# Altium schematic sheet DIYson.SchDoc(DIYson) (continued)

## Library data of the parts
5V_USB +3V3 +5V +48V DIM1 DIM2 IO14 IO15 RX TX = Test_Point: Library Name: josh-lib.SchLib
C1 C3 = C1206 2.2uF 100V X7R 10%: Multilayer Ceramic Capacitor, 2.2 uF, 100 V, ± 10%, X7R, 1206 [3216 Metric]; Manufacturer: Yageo; Manufacturer Part Number: CC1206KKX7R0BB225; Capacitance: 2.2uF; Case/Package: 1206; Category: Ceramic Capacitors; Component Kind: Standard; ComponentLink1Description: http://www.yageo.com/documents/recent/UPY-NP0X7R_MV_100-to-630V_18.pdf?src-supplier=Digikey; ComponentLink1URL: http://www.yageo.com/documents/recent/UPY-NP0X7R_MV_100-to-630V_18.pdf?src-supplier=Digikey; ComponentLink2Description: http://www.yageo.com/documents/recent/UPY-NP0X7R_MV_100-to-630V_21.pdf?src-supplier=Digikey; ComponentLink2URL: http://www.yageo.com/documents/recent/UPY-NP0X7R_MV_100-to-630V_21.pdf?src-supplier=Digikey; ComponentLink3Description: https://www.yageo.com/upload/media/product/products/datasheet/mlcc/UPY-NP0X7R_MV_100-to-630V_21.pdf?src-supplier=Digikey; ComponentLink3URL: https://www.yageo.com/upload/media/product/products/datasheet/mlcc/UPY-NP0X7R_MV_100-to-630V_21.pdf?src-supplier=Digikey; ComponentLink4Description: https://datasheet.octopart.com/CC1206KKX7R0BB225-Yageo-datasheet-101324229.pdf?src-supplier=Newark; ComponentLink4URL: https://datasheet.octopart.com/CC1206KKX7R0BB225-Yageo-datasheet-101324229.pdf?src-supplier=Newark; ComponentLink5Description: https://datasheet.octopart.com/CC1206KKX7R0BB225-Yageo-datasheet-166511530.pdf?src-supplier=Future+Electronics; ComponentLink5URL: https://datasheet.octopart.com/CC1206KKX7R0BB225-Yageo-datasheet-166511530.pdf?src-supplier=Future+Electronics; ComponentLink6Description: LCSC; ComponentLink6URL: https://www.lcsc.com/product-detail/Multilayer-Ceramic-Capacitors-MLCC-SMD-SMT_YAGEO-CC1206KKX7R0BB225_C577211.html; Height: 1.9mm; Length: 0.126inch; Material: Ceramic; Max Operating Temperature: 125°C; Min Operating Temperature: -55°C; Mount: Surface Mount; Packaging: Tape and Reel; Pricing 1: 2000=0.125, 4000=0.123, 6000=0.121, 8000=0.12, 10000=0.117 (USD); RoHS: Yes; RoHS Compliant: Yes; Series: CC; Stock 1: 2718000; Supplier: LCSC; Supplier 1: Future Electronics; Supplier Part Number: C577211; Supplier Part Number 1: 3086827; Thickness: 0.057inch; Tolerance: 10%; Width: 0.063inch; Library Name: josh-lib.SchLib
C2 C4 = CC0603KRX7R7BB105: CAP CER 1UF 16V X7R 0603; Manufacturer: Yageo; Dissipation Factor: 5%; Tolerance: 10%; Capacitance: 1uF; Case Code (Imperial): 0603; Case Code (Metric): 1608; Case/Package: 0603; Category: Ceramic Capacitors; Component Kind: Standard; ComponentLink1Description: https://datasheet.ciiva.com/176/mlccorderingcode-176789.pdf?src-supplier=Digi-Key; ComponentLink1URL: https://datasheet.ciiva.com/176/mlccorderingcode-176789.pdf?src-supplier=Digi-Key; ComponentLink2Description: https://datasheet.ciiva.com/8033/1734573-8033801.pdf?src-supplier=Element14; ComponentLink2URL: https://datasheet.ciiva.com/8033/1734573-8033801.pdf?src-supplier=Element14; ComponentLink3Description: https://datasheet.ciiva.com/7978/1489800-7978143.pdf?src-supplier=Element14; ComponentLink3URL: https://datasheet.ciiva.com/7978/1489800-7978143.pdf?src-supplier=Element14; ComponentLink4Description: https://datasheet.ciiva.com/176/mlccorderingcode-176788.pdf?src-supplier=Digi-Key; ComponentLink4URL: https://datasheet.ciiva.com/176/mlccorderingcode-176788.pdf?src-supplier=Digi-Key; ComponentLink5Description: https://datasheet.ciiva.com/176/mlccorderingcode-176790.pdf?src-supplier=Digi-Key; ComponentLink5URL: https://datasheet.ciiva.com/176/mlccorderingcode-176790.pdf?src-supplier=Digi-Key; ComponentLink6Description: https://datasheet.ciiva.com/5425/upy-gphc-x7r-6-3v-to-50v-8-12304-5425495.pdf?src-supplier=Mouser; ComponentLink6URL: https://datasheet.ciiva.com/5425/upy-gphc-x7r-6-3v-to-50v-8-12304-5425495.pdf?src-supplier=Mouser; ComponentLink7Description: https://datasheet.ciiva.com/16625/upy-gphc-x7r-6-3v-to-50v-8-16625527.pdf?src-supplier=Avnet+Europe; ComponentLink7URL: https://datasheet.ciiva.com/16625/upy-gphc-x7r-6-3v-to-50v-8-16625527.pdf?src-supplier=Avnet+Europe; ComponentLink8Description: https://datasheet.ciiva.com/8033/1734573-8033800.pdf?src-supplier=Element14; ComponentLink8URL: https://datasheet.ciiva.com/8033/1734573-8033800.pdf?src-supplier=Element14; ComponentLink9Description: https://datasheet.ciiva.com/28450/1489800-28450557.pdf?src-supplier=Element14; ComponentLink9URL: https://datasheet.ciiva.com/28450/1489800-28450557.pdf?src-supplier=Element14; Composition: Ceramic; Depth: 0.8mm; Dielectric: X7R; Halogen Free: Halogen Free; Height: 0.8mm; Lead Free: Lead Free; Length: 1.6mm; Manufacturer Part Number: CC0603KRX7R7BB105; Max Operating Temperature: 125°C; Min Operating Temperature: -55°C; Mount: Surface Mount; Number of Pins: 2; Package Quantity: 1; Packaging: Tape and Reel; Pricing 1: 1=0.11000, 10=0.08000, 100=0.03560, 500=0.02544, 1000=0.02000 (USD); Radiation Hardening: No; REACH SVHC: Unknown; RoHS: Yes; Stock 1: 3285653; Supplier 1: Digi-Key; Supplier Part Number 1: 311-1446-6-ND; Termination: SMD/SMT; Thickness: 0.035inch; Voltage: 16V; Voltage Rating: 16V; Voltage Rating (DC): 16V; Width: 0.031inch; Library Name: josh-lib.SchLib
C5 = C1206 2.2uF 100V X7R 10%: Multilayer Ceramic Capacitor, 2.2 uF, 100 V, ± 10%, X7R, 1206 [3216 Metric]; Manufacturer: Yageo; Manufacturer Part Number: CC1206KKX7R0BB225; Voltage Rating: 100V; Capacitance: 2.2uF; Case/Package: 1206; Category: Ceramic Capacitors; Component Kind: Standard; ComponentLink1Description: http://www.yageo.com/documents/recent/UPY-NP0X7R_MV_100-to-630V_18.pdf?src-supplier=Digikey; ComponentLink1URL: http://www.yageo.com/documents/recent/UPY-NP0X7R_MV_100-to-630V_18.pdf?src-supplier=Digikey; ComponentLink2Description: http://www.yageo.com/documents/recent/UPY-NP0X7R_MV_100-to-630V_21.pdf?src-supplier=Digikey; ComponentLink2URL: http://www.yageo.com/documents/recent/UPY-NP0X7R_MV_100-to-630V_21.pdf?src-supplier=Digikey; ComponentLink3Description: https://www.yageo.com/upload/media/product/products/datasheet/mlcc/UPY-NP0X7R_MV_100-to-630V_21.pdf?src-supplier=Digikey; ComponentLink3URL: https://www.yageo.com/upload/media/product/products/datasheet/mlcc/UPY-NP0X7R_MV_100-to-630V_21.pdf?src-supplier=Digikey; ComponentLink4Description: https://datasheet.octopart.com/CC1206KKX7R0BB225-Yageo-datasheet-101324229.pdf?src-supplier=Newark; ComponentLink4URL: https://datasheet.octopart.com/CC1206KKX7R0BB225-Yageo-datasheet-101324229.pdf?src-supplier=Newark; ComponentLink5Description: https://datasheet.octopart.com/CC1206KKX7R0BB225-Yageo-datasheet-166511530.pdf?src-supplier=Future+Electronics; ComponentLink5URL: https://datasheet.octopart.com/CC1206KKX7R0BB225-Yageo-datasheet-166511530.pdf?src-supplier=Future+Electronics; ComponentLink6Description: LCSC; ComponentLink6URL: https://www.lcsc.com/product-detail/Multilayer-Ceramic-Capacitors-MLCC-SMD-SMT_YAGEO-CC1206KKX7R0BB225_C577211.html; Height: 1.9mm; Length: 0.126inch; Material: Ceramic; Max Operating Temperature: 125°C; Min Operating Temperature: -55°C; Mount: Surface Mount; Packaging: Tape and Reel; Pricing 1: 2000=0.125, 4000=0.123, 6000=0.121, 8000=0.12, 10000=0.117 (USD); RoHS: Yes; RoHS Compliant: Yes; Series: CC; Stock 1: 2718000; Supplier: LCSC; Supplier 1: Future Electronics; Supplier Part Number: C577211; Supplier Part Number 1: 3086827; Thickness: 0.057inch; Tolerance: 10%; Width: 0.063inch; Library Name: josh-lib.SchLib
C6 C10 C13-C15 = CC0402KRX7R7BB104: CAP CER 0.1UF 16V X7R 0402; Manufacturer: Yageo; Capacitance: 100nF; Tolerance: 10%; Case Code (Imperial): 0402; Case Code (Metric): 1005; Case/Package: 0402; Category: Ceramic Capacitors; Component Kind: Standard; ComponentLink1Description: https://datasheet.ciiva.com/11788/0900766b80ce67ed-11788364.pdf?src-supplier=RSComponents; ComponentLink1URL: https://datasheet.ciiva.com/11788/0900766b80ce67ed-11788364.pdf?src-supplier=RSComponents; ComponentLink2Description: https://datasheet.ciiva.com/161/mlccorderingcode-161544.pdf?src-supplier=Digi-Key; ComponentLink2URL: https://datasheet.ciiva.com/161/mlccorderingcode-161544.pdf?src-supplier=Digi-Key; ComponentLink3Description: https://datasheet.ciiva.com/11587/upy-gphc-x7r-6-3v-to-50v-8-11587305.pdf?src-supplier=Avnet; ComponentLink3URL: https://datasheet.ciiva.com/11587/upy-gphc-x7r-6-3v-to-50v-8-11587305.pdf?src-supplier=Avnet; ComponentLink4Description: https://datasheet.ciiva.com/161/mlccorderingcode-161546.pdf?src-supplier=Digi-Key; ComponentLink4URL: https://datasheet.ciiva.com/161/mlccorderingcode-161546.pdf?src-supplier=Digi-Key; ComponentLink5Description: https://datasheet.ciiva.com/7978/1489800-7978111.pdf?src-supplier=Element14; ComponentLink5URL: https://datasheet.ciiva.com/7978/1489800-7978111.pdf?src-supplier=Element14; ComponentLink6Description: https://datasheet.ciiva.com/16625/upy-gphc-x7r-6-3v-to-50v-8-16625511.pdf?src-supplier=Avnet+Europe; ComponentLink6URL: https://datasheet.ciiva.com/16625/upy-gphc-x7r-6-3v-to-50v-8-16625511.pdf?src-supplier=Avnet+Europe; ComponentLink7Description: https://datasheet.ciiva.com/161/mlccorderingcode-161545.pdf?src-supplier=Digi-Key; ComponentLink7URL: https://datasheet.ciiva.com/161/mlccorderingcode-161545.pdf?src-supplier=Digi-Key; ComponentLink8Description: https://datasheet.ciiva.com/13395/upy-gphc-x7r-6-3v-to-50v-8-13395676.pdf?src-supplier=Mouser; ComponentLink8URL: https://datasheet.ciiva.com/13395/upy-gphc-x7r-6-3v-to-50v-8-13395676.pdf?src-supplier=Mouser; ComponentLink9Description: https://datasheet.ciiva.com/8033/1734573-8033661.pdf?src-supplier=Element14; ComponentLink9URL: https://datasheet.ciiva.com/8033/1734573-8033661.pdf?src-supplier=Element14; ComponentLink10Description: https://datasheet.ciiva.com/8033/1734573-8033663.pdf?src-supplier=Element14; ComponentLink10URL: https://datasheet.ciiva.com/8033/1734573-8033663.pdf?src-supplier=Element14; ComponentLink11Description: https://datasheet.ciiva.com/28450/1489800-28450335.pdf?src-supplier=Element14; ComponentLink11URL: https://datasheet.ciiva.com/28450/1489800-28450335.pdf?src-supplier=Element14; ComponentLink12Description: LCSC; ComponentLink12URL: https://www.lcsc.com/product-detail/Multilayer-Ceramic-Capacitors-MLCC-SMD-SMT_YAGEO-CC0402KRX7R7BB104_C60474.html; Composition: Ceramic; Depth: 0.5mm; Dielectric: X7R; Halogen Free: Halogen Free; Height: 0.5mm; Lead Free: Lead Free; Length: 1mm; Manufacturer Part Number: CC0402KRX7R7BB104; Max Operating Temperature: 125°C; Min Operating Temperature: -55°C; Mount: Surface Mount; Number of Pins: 2; Package Quantity: 1; Packaging: Tape and Reel; Pricing 1: 1=0.10000, 10=0.03400, 100=0.01500, 500=0.01070, 1000=0.00841, 2500=0.00764, 5000=0.00703 (USD); Radiation Hardening: No; REACH SVHC: No SVHC; RoHS: Yes; Stock 1: 24343579; Supplier: LCSC; Supplier 1: Digi-Key; Supplier Part Number: C60474; Supplier Part Number 1: 311-1338-6-ND; Termination: SMD/SMT; Thickness: 0.022inch; Voltage: 16V; Voltage Rating: 16V; Voltage Rating (DC): 16V; Width: 0.02inch; Library Name: josh-lib.SchLib
C7 = CA45-A-16V-10μF-K: 10uF 16V 2Ω@100kHz ±10% CASE-A-3216 Tantalum Capacitors ROHS; Manufacturer: TORCH; Value: 10uF 16V CASE-A-3216; Component Kind: Standard; ComponentLink1Description: LCSC; ComponentLink1URL: https://www.lcsc.com/product-detail/Tantalum-Capacitors_TORCH-CA45-A-16V-10-F-K_C185774.html; Manufacturer Part Number: CA45-A-16V-10μF-K; Supplier: LCSC; Supplier Part Number: C185774; Library Name: josh-lib.SchLib
C8 C9 = CL31A226KOHNNNE: Cap Ceramic 22uF 16V X5R 10% SMD 1206 85°C Embossed T/R; Manufacturer: Samsung; Capacitance: 22uF; Case Code (Imperial): 1206; Case Code (Metric): 3216; Case/Package: 1206; Category: Ceramic Capacitors; Component Kind: Standard; ComponentLink1Description: https://datasheet.ciiva.com/15195/getdatasheetpartid-354095-15195999.pdf?src-supplier=Verical; ComponentLink1URL: https://datasheet.ciiva.com/15195/getdatasheetpartid-354095-15195999.pdf?src-supplier=Verical; ComponentLink2Description: LCSC; ComponentLink2URL: https://lcsc.com/product-detail/Multilayer-Ceramic-Capacitors-MLCC-SMD-SMT_Samsung-Electro-Mechanics-CL31A226KOHNNNE_C90146.html; Construction: Flat; Depth: 1.6mm; Dielectric: X5R; Height: 1.6mm; Lead Free: Lead Free; Length: 3.2mm; Manufacturer Part Number: CL31A226KOHNNNE; Max Operating Temperature: 85°C; Min Operating Temperature: -55°C; Mount: Surface Mount; Package Quantity: 1; Packaging: Tape and Reel; Pricing 1: 1=0.38270, 10=0.25870, 25=0.25580, 100=0.16220, 250=0.16060, 500=0.12100, 1000=0.12010, 3000=0.09450, 6000=0.08580, 15000=0.07370, 30000=0.06890, 75000=0.06790 (USD); Radiation Hardening: No; RoHS: Yes; RoHS Compliant: Yes; Series: CL; Stock 1: 117894; Supplier: LCSC; Supplier 1: Arrow Electronics; Supplier Part Number: C90146; Supplier Part Number 1: CL31A226KOHNNNE; Thickness: 0.071inch; Tolerance: 10%; Voltage Rating: 16V; Voltage Rating (DC): 16V; Width: 0.063inch; Library Name: josh-lib.SchLib
C11 = CL21A226MOQNNNE: Cap Ceramic 22uF 16V X5R 20% SMD 0805 85°C Embossed Plastic T/R; Manufacturer: Samsung; Capacitance: 22uF; Case Code (Imperial): 0805; Case Code (Metric): 2012; Case/Package: 0805; Category: Ceramic Capacitors; Component Kind: Standard; ComponentLink1Description: https://datasheet.ciiva.com/25724/cl21a226moqnnne-25724832.pdf?src-supplier=Digi-Key; ComponentLink1URL: https://datasheet.ciiva.com/25724/cl21a226moqnnne-25724832.pdf?src-supplier=Digi-Key; ComponentLink2Description: LCSC; ComponentLink2URL: https://www.lcsc.com/product-detail/Multilayer-Ceramic-Capacitors-MLCC-SMD-SMT_Samsung-Electro-Mechanics-CL21A226MOQNNNE_C98190.html; Dielectric: X5R; Height: 1.45mm; Lead Free: Lead Free; Length: 0.079inch; Manufacturer Part Number: CL21A226MOQNNNE; Material: Ceramic; Max Operating Temperature: 85°C; Min Operating Temperature: -55°C; Mount: Surface Mount; Package Quantity: 1; Packaging: Cut Tape; Pricing 1: 2000=0.052, 4000=0.0513, 10000=0.0472, 14000=0.0431, 50000=0.0375, 100000=0.0327 (USD); RoHS: Yes; RoHS Compliant: Yes; Series: CL; Stock 1: 884000; Supplier: LCSC; Supplier 1: Arrow Electronics; Supplier Part Number: C98190; Supplier Part Number 1: CL21A226MOQNNNE; Thickness: 0.055inch; Tolerance: 20%; Value: 22uF 16V X5R; Voltage Rating: 16V; Voltage Rating (DC): 16V; Width: 0.049inch; Library Name: josh-lib.SchLib
C12 C16 C17 = CL05A105KA5NQNC: 1 µF ±10% 25V Ceramic Capacitor X5R 0402 (1005 Metric); Manufacturer: Samsung; Capacitance: 1uF; Case Code (Imperial): 0402; Case/Package: 0402; Category: Ceramic Capacitors; Component Kind: Standard; ComponentLink6Description: LCSC; ComponentLink6URL: https://lcsc.com/product-detail/Multilayer-Ceramic-Capacitors-MLCC-SMD-SMT_Samsung-Electro-Mechanics-CL05A105KA5NQNC_C52923.html; Depth: 0.5mm; Dielectric: X5R; Dielectric Material: Ceramic; Height: 0.5mm; Lead Free: Lead Free; Lead Pitch: 0.3mm; Length: 1mm; Manufacturer Part Number: CL05A105KA5NQNC; Max Operating Temperature: 85°C; Min Operating Temperature: -55°C; Mount: Surface Mount; Number of Pins: 2; Package Quantity: 1; Packaging: Tape and Reel; Radiation Hardening: No; REACH SVHC: No SVHC; RoHS: Yes; Supplier: LCSC; Supplier 1: Arrow Electronics; Supplier Part Number: C52923; Supplier Part Number 1: CL05A105KA5NQNC; Termination: SMD/SMT; Thickness: 0.022inch; Tolerance: 10%; Voltage Rating: 25V; Width: 0.02inch; Library Name: josh-lib.SchLib
CONN1 = TYPE-C 16P QTWT: 3A 1 Surface Mount 16P Female -25℃~+85℃ Type-C SMD USB Connectors ROHS  USB-C; Manufacturer: SHOU HAN; Component Kind: Standard; ComponentLink1Description: LCSC; ComponentLink1URL: https://www.lcsc.com/product-detail/USB-Connectors_SHOU-HAN-TYPE-C-16P-QTWT_C5187472.html; Manufacturer Part Number: TYPE-C 16P QTWT; Supplier: LCSC; Supplier Part Number: C5187472; Value: USB-C; Library Name: josh-lib.SchLib
D1 D2 = DIODE SCHOTTKY 100V 2A DO220AA: Rectifier Diode, Schottky, 1 Phase, 1 Element, 2A, 100V V(RRM), Silicon, DO-220AA; Manufacturer: Vishay; Max Forward Surge Current (Ifsm): 50A; Max Reverse Current: 1uA; Case/Package: DO-220AA; Category: Rectifier Diodes; Component Kind: Standard; ComponentLink1Description: https://datasheet.ciiva.com/18567/ss2ph920&20ss2ph10-18567489.pdf?src-supplier=Digikey; ComponentLink1URL: https://datasheet.ciiva.com/18567/ss2ph920&20ss2ph10-18567489.pdf?src-supplier=Digikey; ComponentLink2Description: https://datasheet.ciiva.com/13948/ss2ph9-13948536.pdf?src-supplier=Digikey; ComponentLink2URL: https://datasheet.ciiva.com/13948/ss2ph9-13948536.pdf?src-supplier=Digikey; ComponentLink3Description: https://datasheet.ciiva.com/15045/ss2ph9ss2ph10-15045638.pdf?src-supplier=Digikey; ComponentLink3URL: https://datasheet.ciiva.com/15045/ss2ph9ss2ph10-15045638.pdf?src-supplier=Digikey; ComponentLink4Description: https://datasheet.ciiva.com/8289/ss2ph9-8289129.pdf?src-supplier=Avnet; ComponentLink4URL: https://datasheet.ciiva.com/8289/ss2ph9-8289129.pdf?src-supplier=Avnet; ComponentLink5Description: https://datasheet.ciiva.com/7962/ss2ph9-7962425.pdf?src-supplier=Element14; ComponentLink5URL: https://datasheet.ciiva.com/7962/ss2ph9-7962425.pdf?src-supplier=Element14; ComponentLink6Description: https://datasheet.ciiva.com/22695/1911775-22695932.pdf?src-supplier=Element14; ComponentLink6URL: https://datasheet.ciiva.com/22695/1911775-22695932.pdf?src-supplier=Element14; ComponentLink7Description: LCSC; ComponentLink7URL: https://www.lcsc.com/product-detail/Schottky-Diodes_Vishay-Intertech-SS2PH10-M3-84A_C77393.html; Forward Current: 2A; Height: 1.15mm; Lead Free: 5697LeadFree; Manufacturer Part Number: SS2PH10-M3/84A; Max Operating Temperature: 175°C; Max Reverse Voltage (DC): 100V; Max Surge Current: 50A; Min Operating Temperature: -55°C; Mount: Surface Mount; Number of Pins: 2; Package Quantity: 3000; Packaging: Tape and Reel; Peak Non-Repetitive Surge Current: 50A; Pricing 1: 1=0.45, 10=0.35, 100=0.21, 500=0.19442, 1000=0.13221, 3000=0.12171, 6000=0.11666, 9000=0.10499, 30000=0.10343, 75000=0.09721 (USD); Radiation Hardening: No; Reach SVHC Compliant: Unknown; Reverse Voltage: 100V; RoHS: Yes; RoHS Compliant: Yes; Series: eSMP®; Stock 1: 71131; Supplier: LCSC; Supplier 1: Digikey; Supplier Part Number: C77393; Supplier Part Number 1: SS2PH10-M3/84AGICT-ND; Library Name: josh-lib.SchLib
D3 = B360A: 60V Single 3A 700mV@3A SMA(DO-214AC) Schottky Barrier Diodes (SBD) ROHS; Manufacturer: FUXINSEMI; Value: 60V 3A; Component Kind: Standard; ComponentLink1Description: LCSC; ComponentLink1URL: https://www.lcsc.com/product-detail/Schottky-Barrier-Diodes-SBD_FUXINSEMI-B360A_C6807778.html; Manufacturer Part Number: B360A; Supplier: LCSC; Supplier Part Number: C6807778; Library Name: josh-lib.SchLib
D5-D7 = LESD5D5.0CT1G: 5.6V 18.6V 5V Bi-Directional SOD-523 ESD Protection Devices ROHS; Manufacturer: LRC; Component Kind: Standard; ComponentLink1Description: LCSC; ComponentLink1URL: https://www.lcsc.com/product-detail/Diodes-ESD_LRC-LESD5D5-0CT1G_C383211.html; Manufacturer Part Number: LESD5D5.0CT1G; Supplier: LCSC; Supplier Part Number: C383211; Library Name: josh-lib.SchLib
D8 = SMAJ54A-13-F: SMAJ Series 54 V 400 W Surface Mount Uni-Directional TVS Diode - DO-214AC; Manufacturer: Diodes; Breakdown Voltage: 60V; Clamping Voltage: 87.1V; Clamping Voltage-Max: 38.9V; Diode Type: ZENER; Direction: Unidirectional; Leakage Current: 5uA; Max Breakdown Voltage: 29.5V; Max Power Dissipation: 400W; Max Surge Current: 40A; Number of Elements: 1; Peak Pulse Current: 4.6A; Power Line Protection: No; Test Current: 1mA; Case/Package: SMA; Category: TVS Diodes; Component Kind: Standard; ComponentLink1Description: http://components.arrow.com/part/dataview/41509896S7614698N2867?src-supplier=Arrow+Electronics; ComponentLink1URL: http://components.arrow.com/part/dataview/41509896S7614698N2867?src-supplier=Arrow+Electronics; ComponentLink2Description: http://www.mouser.com/ds/2/115/ds19005-73607.pdf?src-supplier=Mouser; ComponentLink2URL: http://www.mouser.com/ds/2/115/ds19005-73607.pdf?src-supplier=Mouser; ComponentLink3Description: https://www.diodes.com/assets/Datasheets/ds19005.pdf?src-supplier=Digikey; ComponentLink3URL: https://www.diodes.com/assets/Datasheets/ds19005.pdf?src-supplier=Digikey; ComponentLink4Description: http://www.diodes.com/datasheets/ds19005.pdf?src-supplier=Avnet; ComponentLink4URL: http://www.diodes.com/datasheets/ds19005.pdf?src-supplier=Avnet; ComponentLink5Description: http://www.diodes.com/_files/datasheets/ds19005.pdf?src-supplier=Future+Electronics; ComponentLink5URL: http://www.diodes.com/_files/datasheets/ds19005.pdf?src-supplier=Future+Electronics; ComponentLink6Description: https://datasheet.octopart.com/SMAJ54A-13-F-Diodes-Inc.-datasheet-62305222.pdf?src-supplier=Future+Electronics; ComponentLink6URL: https://datasheet.octopart.com/SMAJ54A-13-F-Diodes-Inc.-datasheet-62305222.pdf?src-supplier=Future+Electronics; ComponentLink7Description: https://datasheet.octopart.com/SMAJ54A-13-F-Diodes-Inc.-datasheet-157073627.pdf?src-supplier=Newark; ComponentLink7URL: https://datasheet.octopart.com/SMAJ54A-13-F-Diodes-Inc.-datasheet-157073627.pdf?src-supplier=Newark; ComponentLink8Description: https://datasheet.octopart.com/SMAJ54A-13-F-Diodes-Inc.-datasheet-157073627.pdf?src-supplier=TME; ComponentLink8URL: https://datasheet.octopart.com/SMAJ54A-13-F-Diodes-Inc.-datasheet-157073627.pdf?src-supplier=TME; ComponentLink9Description: LCSC; ComponentLink9URL: https://www.lcsc.com/product-detail/ESD-and-Surge-Protection-TVS-ESD_Diodes-Incorporated-SMAJ54A-13-F_C134996.html; Lead Free: Lead Free; Length: 4.6mm; Manufacturer Part Number: SMAJ54A-13-F; Max Operating Temperature: 150°C; Max Reverse Leakage Current: 5uA; Min Breakdown Voltage: 60V; Min Operating Temperature: -55°C; Mount: Surface Mount; Number of Pins: 2; Number of Unidirectional Channels: 1; Package Quantity: 5000; Packaging: Tape and Reel; Peak Pulse Power: 400W; Polarity: Unidirectional; Power Dissipation: 400W; Pricing 1: 1=0.29, 10=0.197, 100=0.093, 500=0.088, 1000=0.083 (USD); Reverse Breakdown Voltage: 60V; Reverse Standoff Voltage: 54V; RoHS: Yes; RoHS Compliant: Yes; Series: -; Stock 1: 1498; Supplier: LCSC; Supplier 1: Digikey; Supplier Part Number: C134996; Supplier Part Number 1: 31-SMAJ54A-13-FCT-ND; Termination: SMD/SMT; Working Voltage: 54V; Library Name: josh-lib.SchLib
J1 J2 J4 = 2059-302/998-403: WAGO 2059-302/998-403 SMD Terminal Block 3mm 2 Pole White Tape & Reel; Current Rating: 3A; Number of Contacts: 2; Stripping Length: -5.5mm; Voltage Rating: 160V; Wire/Cable Gauge: 26AWG; Category: Terminal Blocks; Color: White; Component Kind: Standard; ComponentLink1Description: https://datasheet.ciiva.com/41441/001208172-da-01-de-smd-leiterplattenklemme-2059-302-998-404-41441808.pdf?src-supplier=Conrad; ComponentLink1URL: https://datasheet.ciiva.com/41441/001208172-da-01-de-smd-leiterplattenklemme-2059-302-998-404-41441808.pdf?src-supplier=Conrad; ComponentLink2Description: https://datasheet.ciiva.com/46435/1860449-46435420.pdf?src-supplier=Element14; ComponentLink2URL: https://datasheet.ciiva.com/46435/1860449-46435420.pdf?src-supplier=Element14; ComponentLink3Description: LCSC; ComponentLink3URL: https://www.lcsc.com/product-detail/Spring-Clamp-System-Terminal-Block_WAGO-2059-302-998-403_C2765060.html; ComponentLink4Description: DigiKey; ComponentLink4URL: https://www.digikey.com/en/products/detail/wago-corporation/2059-302-998-403/15532751; Contact Material: Tin; Contact Plating: Tin; Height: 2.7mm; Lead Free: Lead Free; Length: 5.9mm; Manufacturer: WAGO; Manufacturer Part Number: 2059-302/998-403; Number of Positions: 2; Pitch: 3mm; Pricing 1: 1=1.49, 10=0.826, 25=0.6944, 50=0.6234, 100=0.5702, 250=0.51956, 500=0.49216 (USD); Reach SVHC Compliant: No; RoHS: Yes; RoHS Compliant: Yes; Stock 1: 19034; Supplier: LCSC; Supplier 1: Digikey; Supplier Part Number: C2765060; Supplier Part Number 1: 2946-2059-302/998-403CT-ND; Width: 6.5mm; Library Name: josh-lib.SchLib
L1 L2 = IND 68uH 600mA 175mOhm SMD Shielded: 600mA 68uH Shielded inductor ±30% 600mA SMD Power Inductors ROHS; Manufacturer: SXN; Manufacturer Part Number: SMDRS7045-680N; Component Kind: Standard; ComponentLink1Description: LCSC; ComponentLink1URL: https://www.lcsc.com/product-detail/Power-Inductors_SXN-Shun-Xiang-Nuo-Elec-SMDRS7045-680N_C163593.html; Supplier: LCSC; Supplier Part Number: C163593; Library Name: josh-lib.SchLib
L3 = NR6045T330M: FIXED IND 33UH 1.4A 188.5 MOHM; Manufacturer: Taiyo Yuden; Core Material: Ferrite; DC Resistance (DCR): 188.5mR; Inductance Tolerance: 20%; Max DC Current: 1.5A; Self Resonant Frequency: 6MHz; Shielding: Shielded; Test Frequency: 100kHz; Case/Package: Nonstandard; Component Kind: Standard; ComponentLink1Description: https://www.yuden.co.jp/productdata/catalog/wound04_e.pdf?src-supplier=Digi-Key; ComponentLink1URL: https://www.yuden.co.jp/productdata/catalog/wound04_e.pdf?src-supplier=Digi-Key; ComponentLink2Description: http://www.yuden.co.jp/productdata/catalog/en/wound04_e.pdf?src-supplier=Digi-Key; ComponentLink2URL: http://www.yuden.co.jp/productdata/catalog/en/wound04_e.pdf?src-supplier=Digi-Key; ComponentLink3Description: http://www.yuden.co.jp/or/product/category/inductor/NR6045T330M.pdf?src-supplier=Avnet; ComponentLink3URL: http://www.yuden.co.jp/or/product/category/inductor/NR6045T330M.pdf?src-supplier=Avnet; ComponentLink4Description: LCSC; ComponentLink4URL: https://www.lcsc.com/product-detail/Power-Inductors_Taiyo-Yuden-NR6045T330M_C92942.html; Composition: Wirewound; Current Rating: 1.4A; Depth: 6.2mm; Halogen Free: Halogen Free; Height: 4.5mm; Height - Seated (Max): 0.177inch; Inductance: 33uH; Length: 0.236inch; Manufacturer Part Number: NR6045T330M; Max Operating Temperature: 120°C; Min Operating Temperature: -25°C; Mount: Surface Mount; Number of Pins: 2; Package Quantity: 1500; Packaging: Tape and Reel; Pricing 1: 1 = 0.44000, 10 = 0.38200, 100 = 0.27310, 500 = 0.22942 (USD); Radiation Hardening: No; REACH SVHC: Unknown; RMS Current (Irms): 1.4A; RoHS: Yes; Saturation Current: 1.5A; Stock 1: 26538; Supplier: LCSC; Supplier 1: Digi-Key; Supplier Part Number: C92942; Supplier Part Number 1: 587-2634-1-ND; Termination: SMD/SMT; Tolerance: 20%; Width: 0.236inch; Library Name: josh-lib.SchLib
MP1 MP2 = SMTSOM330STR: M3 Round Nut Nuts ROHS 3mm height; Manufacturer: YIYUAN; Component Kind: Standard; ComponentLink1Description: LCSC; ComponentLink1URL: https://www.lcsc.com/product-detail/Other-nuts_YIYUAN-SMTSOM330STR_C5221849.html; Manufacturer Part Number: SMTSOM330STR; Supplier: LCSC; Supplier Part Number: C5221849; Library Name: josh-lib.SchLib
NTC1 = NXFT15XH103FEAB021: Thermistor 10kOhm 1% 2-Pin Radial Bulk NTC; Manufacturer: Murata; Category: Thermistors; Component Kind: Standard; ComponentLink1Description: DigiKey; ComponentLink1URL: https://www.digikey.com/en/products/detail/murata-electronics/NXFT15XH103FEAB021/13904892; Manufacturer Part Number: NXFT15XH103FEAB021; Pricing 1: 1=0.39, 5=0.328, 10=0.305, 25=0.2792, 50=0.2622, 100=0.247, 500=0.21756, 1000=0.20706, 5000=0.1867 (USD); RoHS: No; Stock 1: 1975; Supplier 1: Digikey; Supplier Part Number 1: 490-NXFT15XH103FEAB021-ND; Library Name: josh-lib.SchLib
R1 R3 = R0603 3.01k 1% 100mW: SMD Chip Resistor, 3.01 kOhm, ± 1%, 100 mW, 0603 [1608 Metric], Thick Film, General Purpose; Manufacturer: Yageo; Manufacturer Part Number: RC0603FR-073K01L; Max Power Dissipation: 100mW; Resistance: 3.01kR; Temperature Coefficient: 100ppm/°C; Voltage Rating: 50V; Case Code (Imperial): 0603; Case Code (Metric): 1608; Case/Package: 0603; Category: Chip SMD Resistors; Component Kind: Standard; ComponentLink1Description: https://datasheet.ciiva.com/11808/0900766b80f96399-11808271.pdf?src-supplier=Rs; ComponentLink1URL: https://datasheet.ciiva.com/11808/0900766b80f96399-11808271.pdf?src-supplier=Rs; ComponentLink2Description: LCSC; ComponentLink2URL: https://www.lcsc.com/product-detail/Chip-Resistor-Surface-Mount_YAGEO-RC0603FR-073K01L_C137732.html; Construction: Rectangular; Depth: 0.8mm; Features: Moisture Resistant; Height: 0.45mm; Lead Free: Lead Free; Length: 1.6mm; Max Operating Temperature: 155°C; Military Standard: Not; Min Operating Temperature: -55°C; Mount: Surface Mount; Number of Terminations: 2; Packaging: Tape and Reel; Power Rating: 100mW; Pricing 1: 1=0.1, 10=0.019, 50=0.0112, 100=0.0093, 500=0.00622, 1000=0.00532 (USD); RoHS: Yes; RoHS Compliant: Yes; Series: RC; Size Code: 0603; Stock 1: 77755; Supplier: LCSC; Supplier 1: Digikey; Supplier Part Number: C137732; Supplier Part Number 1: 311-3.01KHRCT-ND; Technology: THICK FILM; Termination: SMD/SMT; Tolerance: 1%; Voltage Rating (DC): 50V; Library Name: josh-lib.SchLib
R2 R4 = R0603 33.2k 1% 100mW: SMD Chip Resistor, 33.2 kOhm, ± 1%, 100 mW, 0603 [1608 Metric], Thick Film, General Purpose; Manufacturer: Yageo; Manufacturer Part Number: RC0603FR-0733K2L; ESR (Equivalent Series Resistance): 70R; Frequency: 12MHz; Frequency Tolerance: 0.002%; Max Power Dissipation: 100mW; Resistance: 33.2kR; Temperature Coefficient: 100ppm/°C; Voltage Rating: 50V; Case Code (Imperial): 0603; Case Code (Metric): 1608; Case/Package: 0603; Category: Chip SMD Resistors; Component Kind: Standard; ComponentLink1Description: https://datasheet.ciiva.com/11808/0900766b80f96399-11808316.pdf?src-supplier=Rs; ComponentLink1URL: https://datasheet.ciiva.com/11808/0900766b80f96399-11808316.pdf?src-supplier=Rs; ComponentLink2Description: LCSC; ComponentLink2URL: https://www.lcsc.com/product-detail/Chip-Resistor-Surface-Mount_YAGEO-RC0603FR-0733K2L_C185338.html; Construction: Rectangular; Depth: 0.8mm; Features: Moisture Resistant; Height: 0.45mm; Lead Free: Lead Free; Length: 1.6mm; Max Operating Temperature: 155°C; Military Standard: Not; Min Operating Temperature: -55°C; Mount: Surface Mount; Number of Terminations: 2; Packaging: Tape and Reel; Power Rating: 100mW; Pricing 1: 1=0.1, 10=0.019, 50=0.0112, 100=0.0093, 500=0.00622, 1000=0.00532 (USD); Radiation Hardening: No; Reach SVHC Compliant: No; RoHS: Yes; RoHS Compliant: Yes; Series: RC; Size Code: 0603; Stock 1: 493153; Supplier: LCSC; Supplier 1: Digikey; Supplier Part Number: C185338; Supplier Part Number 1: 311-33.2KHRCT-ND; Technology: THICK FILM; Termination: SMD/SMT; Tolerance: 1%; Voltage Rating (DC): 50V; Library Name: josh-lib.SchLib
R5 = AC0402FR-07118KL: Res Thick Film 0402 118K Ohm 1% 0.063W(1/16W) ±100ppm/C Pad SMD Automotive T/R; Manufacturer: Yageo; Max Power Dissipation: 63mW; Resistance: 118kR; Temperature Coefficient: 100ppm/°C; Voltage Rating: 50V; Case/Package: 0402; Category: Chip SMD Resistors; Component Kind: Standard; ComponentLink1Description: http://www.yageo.com/NewPortal/yageodocoutput?fileName=/pdf/R-Chip/PYu-AC_51_RoHS_L_6.pdf&src-supplier=Digikey; ComponentLink1URL: http://www.yageo.com/NewPortal/yageodocoutput?fileName=/pdf/R-Chip/PYu-AC_51_RoHS_L_6.pdf&src-supplier=Digikey; ComponentLink2Description: https://datasheet.octopart.com/AC0402FR-07118KL-Yageo-datasheet-166720450.pdf?src-supplier=Future+Electronics; ComponentLink2URL: https://datasheet.octopart.com/AC0402FR-07118KL-Yageo-datasheet-166720450.pdf?src-supplier=Future+Electronics; ComponentLink3Description: LCSC; ComponentLink3URL: https://www.lcsc.com/product-detail/Chip-Resistor-Surface-Mount_YAGEO-AC0402FR-07118KL_C144804.html; Features: Moisture Resistant,Automotive AEC-Q200; Height: 0.015inch; Length: 0.039inch; Manufacturer Part Number: AC0402FR-07118KL; Max Operating Temperature: 155°C; Min Operating Temperature: -55°C; Number of Terminations: 2; Packaging: Tape and Reel; Pricing 1: 1=0.1, 10=0.01, 50=0.0086, 100=0.0071, 500=0.00664, 1000=0.00612 (USD); RoHS: Yes; RoHS Compliant: Yes; Series: AC; Stock 1: 77; Supplier: LCSC; Supplier 1: Digikey; Supplier Part Number: C144804; Supplier Part Number 1: 13-AC0402FR-07118KLCT-ND; Tolerance: 1%; Width: 0.02inch; Library Name: josh-lib.SchLib
R6 = RC0402FR-0722K1L: SMD Chip Resistor, 22.1 kOhm, ï¿½ 1%, 62.5 mW, 0402 [1005 Metric], Thick Film, General Purpose; Manufacturer: Yageo; Max Power Dissipation: 63mW; Power Rating: 62.5mW; Resistance: 22.1kR; Temperature Coefficient: 100ppm/°C; Voltage Rating: 50V; Case Code (Imperial): 0402; Case Code (Metric): 1005; Case/Package: 0402; Category: Chip SMD Resistors; Component Kind: Standard; ComponentLink1Description: https://datasheet.ciiva.com/2553/pyu-r-int-thick-7-2553200.pdf?src-supplier=Digikey; ComponentLink1URL: https://datasheet.ciiva.com/2553/pyu-r-int-thick-7-2553200.pdf?src-supplier=Digikey; ComponentLink2Description: https://datasheet.ciiva.com/2553/pyu-r-int-thick-7-2553201.pdf?src-supplier=Digikey; ComponentLink2URL: https://datasheet.ciiva.com/2553/pyu-r-int-thick-7-2553201.pdf?src-supplier=Digikey; ComponentLink3Description: https://datasheet.ciiva.com/2553/pyu-r-int-thick-7-2553202.pdf?src-supplier=Digikey; ComponentLink3URL: https://datasheet.ciiva.com/2553/pyu-r-int-thick-7-2553202.pdf?src-supplier=Digikey; ComponentLink4Description: https://datasheet.ciiva.com/7983/1018594-7983501.pdf?src-supplier=Element14; ComponentLink4URL: https://datasheet.ciiva.com/7983/1018594-7983501.pdf?src-supplier=Element14; ComponentLink5Description: https://datasheet.ciiva.com/15189/getdatasheetpartid-251817-15189388.pdf?src-supplier=Verical; ComponentLink5URL: https://datasheet.ciiva.com/15189/getdatasheetpartid-251817-15189388.pdf?src-supplier=Verical; ComponentLink6Description: LCSC; ComponentLink6URL: https://www.lcsc.com/product-detail/Chip-Resistor-Surface-Mount_YAGEO-RC0402FR-0722K1L_C163478.html; Construction: Rectangular; Depth: 0.5mm; Features: Moisture Resistant; Height: 0.016inch; Lead Free: Lead Free; Length: 0.039inch; Manufacturer Part Number: RC0402FR-0722K1L; Max Operating Temperature: 155°C; Military Standard: Not; Min Operating Temperature: -55°C; Number of Terminations: 2; Package Quantity: 1; Packaging: Tape and Reel; Pricing 1: 10000=0.0007, 50000=0.0007, 200000=0.0006, 500000=0.0006, 1250000=0.0006 (USD); Reach SVHC Compliant: No; Resistance Tolerance: 1%; Resistor Type: Thick Film; RoHS: Yes; RoHS Compliant: Yes; Series: RC; Stock 1: 30000; Supplier: LCSC; Supplier 1: Future Electronics; Supplier Part Number: C163478; Supplier Part Number 1: 7052236; Technology: THICK FILM; Termination: SMD/SMT; Tolerance: 1%; Voltage Rating (DC): 50V; Width: 0.02inch; Working Voltage: 50V; Library Name: josh-lib.SchLib
R7 R8 = RC0402FR-07100KL: Res General Purpose Thick Film 0402 100K Ohm 1% 1/16W ±100ppm/°C Molded SMD Paper T/R; Manufacturer: Yageo; Max Power Dissipation: 63mW; Resistance: 100kR; Voltage Rating: 50V; Case Code (Imperial): 0402; Case Code (Metric): 1005; Case/Package: 0402; Category: Chip SMD Resistors; Component Kind: Standard; ComponentLink1Description: https://datasheet.ciiva.com/11819/0900766b80c66297-11819452.pdf?src-supplier=RSComponents; ComponentLink1URL: https://datasheet.ciiva.com/11819/0900766b80c66297-11819452.pdf?src-supplier=RSComponents; ComponentLink2Description: https://datasheet.ciiva.com/22747/1018594-22747509.pdf?src-supplier=Element14; ComponentLink2URL: https://datasheet.ciiva.com/22747/1018594-22747509.pdf?src-supplier=Element14; ComponentLink3Description: https://datasheet.ciiva.com/2453/pyu-r-int-thick-7-2453361.pdf?src-supplier=Digi-Key; ComponentLink3URL: https://datasheet.ciiva.com/2453/pyu-r-int-thick-7-2453361.pdf?src-supplier=Digi-Key; ComponentLink4Description: https://datasheet.ciiva.com/2453/pyu-r-int-thick-7-2453362.pdf?src-supplier=Digi-Key; ComponentLink4URL: https://datasheet.ciiva.com/2453/pyu-r-int-thick-7-2453362.pdf?src-supplier=Digi-Key; ComponentLink5Description: https://datasheet.ciiva.com/2453/pyu-r-int-thick-7-2453363.pdf?src-supplier=Digi-Key; ComponentLink5URL: https://datasheet.ciiva.com/2453/pyu-r-int-thick-7-2453363.pdf?src-supplier=Digi-Key; ComponentLink6Description: https://datasheet.ciiva.com/15189/getdatasheetpartid-323696-15189334.pdf?src-supplier=Verical; ComponentLink6URL: https://datasheet.ciiva.com/15189/getdatasheetpartid-323696-15189334.pdf?src-supplier=Verical; ComponentLink7Description: https://datasheet.ciiva.com/12667/pyu-rc0402-51-rohs-l-6-r-12667930.pdf?src-supplier=Mouser; ComponentLink7URL: https://datasheet.ciiva.com/12667/pyu-rc0402-51-rohs-l-6-r-12667930.pdf?src-supplier=Mouser; Composition: Thick Film; Depth: 0.5mm; Features: Moisture Resistant; Height: 0.016inch; Lead Free: Lead Free; Length: 0.039inch; Manufacturer Part Number: RC0402FR-07100KL; Max Operating Temperature: 155°C; Military Standard: Not; Min Operating Temperature: -55°C; Number of Terminations: 2; Package Quantity: 1; Packaging: Tape and Reel; Power Rating: 63mW; Pricing 1: 1=0.10000, 10=0.01600, 100=0.00640, 1000=0.00289, 2500=0.00251, 5000=0.00207 (USD); REACH SVHC: No SVHC; RoHS: Yes; Stock 1: 13189938; Supplier: LCSC; Supplier 1: Digi-Key; Supplier Part Number: C60491; Supplier Part Number 1: 311-100KLRDKR-ND; Temperature Coefficient: 100ppm/°C; Termination: SMD/SMT; Tolerance: 1%; Width: 0.02inch; Working Voltage: 50V; Library Name: josh-lib.SchLib
R9 = RC0402JR-0710KL: SMD Chip Resistor, 10 kOhm, ± 5%, 63 mW, 0402 [1005 Metric], Thick Film, General Purpose; Manufacturer: Yageo; Max Power Dissipation: 63mW; Power Rating: 62.5mW; Resistance: 10kR; Temperature Coefficient: 100ppm/°C; Voltage Rating: 50V; Case Code (Imperial): 0402; Case Code (Metric): 1005; Case/Package: 0402; Category: Chip SMD Resistors; Component Kind: Standard; ComponentLink1Description: https://datasheet.ciiva.com/2452/pyu-r-int-thick-7-2452627.pdf?src-supplier=Digi-Key; ComponentLink1URL: https://datasheet.ciiva.com/2452/pyu-r-int-thick-7-2452627.pdf?src-supplier=Digi-Key; ComponentLink2Description: https://datasheet.ciiva.com/2452/pyu-r-int-thick-7-2452628.pdf?src-supplier=Digi-Key; ComponentLink2URL: https://datasheet.ciiva.com/2452/pyu-r-int-thick-7-2452628.pdf?src-supplier=Digi-Key; ComponentLink3Description: https://datasheet.ciiva.com/2452/pyu-r-int-thick-7-2452626.pdf?src-supplier=Digi-Key; ComponentLink3URL: https://datasheet.ciiva.com/2452/pyu-r-int-thick-7-2452626.pdf?src-supplier=Digi-Key; ComponentLink4Description: https://datasheet.ciiva.com/12669/pyu-rc0402-51-rohs-l-6-r-12669687.pdf?src-supplier=Mouser; ComponentLink4URL: https://datasheet.ciiva.com/12669/pyu-rc0402-51-rohs-l-6-r-12669687.pdf?src-supplier=Mouser; ComponentLink5Description: https://datasheet.ciiva.com/7850/1018594-7850136.pdf?src-supplier=Element14; ComponentLink5URL: https://datasheet.ciiva.com/7850/1018594-7850136.pdf?src-supplier=Element14; ComponentLink6Description: https://datasheet.ciiva.com/7850/1018594-7850137.pdf?src-supplier=Element14; ComponentLink6URL: https://datasheet.ciiva.com/7850/1018594-7850137.pdf?src-supplier=Element14; ComponentLink7Description: LCSC; ComponentLink7URL: https://www.lcsc.com/product-detail/Chip-Resistor-Surface-Mount_YAGEO-RC0402JR-0710KL_C60489.html; Construction: Rectangular; Depth: 0.5mm; Features: Moisture Resistant; Height: 0.016inch; Lead Free: 5697LeadFree; Length: 0.039inch; Manufacturer Part Number: RC0402JR-0710KL; Max Operating Temperature: 155°C; Military Standard: Not; Min Operating Temperature: -55°C; Number of Terminations: 2; Package Quantity: 1; Packaging: Tape and Reel; Pricing 1: 10000=0.0008, 100000=0.0008, 400000=0.0008, 1250000=0.0007, 4000000=0.0007 (USD); Reach SVHC Compliant: Unknown; Resistance Tolerance: 5%; Resistor Type: Thick Film; RoHS: Yes; RoHS Compliant: Yes; Series: RC; Size Code: 0402; Stock 1: 45180000; Supplier: LCSC; Supplier 1: Future Electronics; Supplier Part Number: C60489; Supplier Part Number 1: 4356762; Termination: SMD/SMT; Tolerance: 5%; Voltage Rating (AC): 50V; Width: 0.02inch; Library Name: josh-lib.SchLib
R10 R17 = R0402 10k 1% 63mW 50V: SMD Chip Resistor, 10 kOhm, ï¿½ 1%, 62.5 mW, 0402 [1005 Metric], Thick Film, General Purpose; Manufacturer: Yageo; Manufacturer Part Number: RC0402FR-0710KL; Max Power Dissipation: 63mW; Power Rating: 62.5mW; Resistance: 10kR; Temperature Coefficient: 100ppm/°C; Voltage Rating: 50V; Case Code (Imperial): 0402; Case Code (Metric): 1005; Case/Package: 0402; Category: Chip SMD Resistors; Component Kind: Standard; ComponentLink1Description: https://datasheet.ciiva.com/7660/38568-7660787.pdf?src-supplier=Element14; ComponentLink1URL: https://datasheet.ciiva.com/7660/38568-7660787.pdf?src-supplier=Element14; ComponentLink2Description: https://datasheet.ciiva.com/7983/1018594-7983486.pdf?src-supplier=Element14; ComponentLink2URL: https://datasheet.ciiva.com/7983/1018594-7983486.pdf?src-supplier=Element14; ComponentLink3Description: https://datasheet.ciiva.com/22747/1018594-22747512.pdf?src-supplier=Element14; ComponentLink3URL: https://datasheet.ciiva.com/22747/1018594-22747512.pdf?src-supplier=Element14; ComponentLink4Description: https://datasheet.ciiva.com/7849/1784430-7849813.pdf?src-supplier=Element14; ComponentLink4URL: https://datasheet.ciiva.com/7849/1784430-7849813.pdf?src-supplier=Element14; ComponentLink5Description: https://datasheet.ciiva.com/7849/1686128-7849814.pdf?src-supplier=Element14; ComponentLink5URL: https://datasheet.ciiva.com/7849/1686128-7849814.pdf?src-supplier=Element14; ComponentLink6Description: https://datasheet.ciiva.com/7849/1784430-7849815.pdf?src-supplier=Element14; ComponentLink6URL: https://datasheet.ciiva.com/7849/1784430-7849815.pdf?src-supplier=Element14; ComponentLink7Description: https://datasheet.ciiva.com/12667/pyu-rc0402-51-rohs-l-6-r-12667067.pdf?src-supplier=Mouser; ComponentLink7URL: https://datasheet.ciiva.com/12667/pyu-rc0402-51-rohs-l-6-r-12667067.pdf?src-supplier=Mouser; ComponentLink8Description: LCSC; ComponentLink8URL: https://www.lcsc.com/product-detail/Chip-Resistor-Surface-Mount_YAGEO-RC0402FR-0710KL_C60490.html; Construction: Rectangular; Depth: 0.5mm; Features: Moisture Resistant; Lead Free: Lead Free; Lead Pitch: 0.2mm; Length: 1mm; Max Operating Temperature: 155°C; Military Standard: Not; Min Operating Temperature: -55°C; Number of Pins: 2; Number of Terminations: 2; Packaging: Tape and Reel; Pricing 1: 10000=0.0008, 100000=0.0007, 300000=0.0007, 750000=0.0007, 2000000=0.0006 (USD); Reach SVHC Compliant: Unknown; Resistance Tolerance: 1%; Resistor Type: Thick Film; RoHS: Yes; RoHS Compliant: Yes; Series: RC; Stock 1: 7380000; Supplier: LCSC; Supplier 1: Future Electronics; Supplier Part Number: C60490; Supplier Part Number 1: 3388022; Termination: SMD/SMT; Tolerance: 1%; Voltage Rating (DC): 50V; Width: 0.5mm; Library Name: josh-lib.SchLib
R11 R12 R15 = RC0402FR-070RL: Res Thick Film 0402 0 Ohm Molded SMD SMD Paper T/R; Manufacturer: Yageo; Power Rating: 62.5mW; Resistance: 0mR; Temperature Coefficient: 200ppm/°C; Tolerance: 1%; Voltage Rating: 50V; Case Code (Imperial): 0402; Case Code (Metric): 1005; Case/Package: 0402; Category: Chip SMD Resistors; Component Kind: Standard; ComponentLink1Description: https://datasheet.ciiva.com/8896/pyu-rc0402-51-rohs-l-6-8896149.pdf?src-supplier=Avnet; ComponentLink1URL: https://datasheet.ciiva.com/8896/pyu-rc0402-51-rohs-l-6-8896149.pdf?src-supplier=Avnet; ComponentLink2Description: https://datasheet.ciiva.com/16790/pyu-rc0402-51-rohs-l-6-r-16790444.pdf?src-supplier=Future+Electronics; ComponentLink2URL: https://datasheet.ciiva.com/16790/pyu-rc0402-51-rohs-l-6-r-16790444.pdf?src-supplier=Future+Electronics; ComponentLink3Description: https://datasheet.ciiva.com/28419/pyu-rc0402-51-rohs-l-6-28419661.pdf?src-supplier=Avnet+Europe; ComponentLink3URL: https://datasheet.ciiva.com/28419/pyu-rc0402-51-rohs-l-6-28419661.pdf?src-supplier=Avnet+Europe; ComponentLink4Description: https://datasheet.ciiva.com/16869/pyu-rc0402-51-rohs-l-6-16869518.pdf?src-supplier=Avnet+Europe; ComponentLink4URL: https://datasheet.ciiva.com/16869/pyu-rc0402-51-rohs-l-6-16869518.pdf?src-supplier=Avnet+Europe; ComponentLink5Description: LCSC; ComponentLink5URL: https://www.lcsc.com/product-detail/Chip-Resistor-Surface-Mount_YAGEO-RC0402FR-070RL_C106231.html; Composition: Thick Film; Contact Plating: Tin,Matte; Height: 0.35mm; Lead Free: Lead Free; Length: 1mm; Manufacturer Part Number: RC0402FR-070RL; Max Operating Temperature: 155°C; Min Operating Temperature: -55°C; Mount: Surface Mount; Packaging: Tape and Reel; Pricing 1: 1=0.10000, 10=0.01300, 25=0.00920, 50=0.00720, 100=0.00530, 250=0.00400, 500=0.00320, 1000=0.00236, 5000=0.00169 (USD); Radiation Hardening: No; RoHS: Yes; Stock 1: 1551811; Supplier: LCSC; Supplier 1: Digi-Key; Supplier Part Number: C106231; Supplier Part Number 1: 311-0.0LRCT-ND; Termination: SMD/SMT; Width: 0.5mm; Library Name: josh-lib.SchLib
R13 R14 = R0402 5.1k 1% 63mW: SMD Chip Resistor, 5.1 kOhm, ï¿½ 1%, 62.5 mW, 0402 [1005 Metric], Thick Film, General Purpose; Manufacturer: Yageo; Manufacturer Part Number: RC0402FR-075K1L; Max Power Dissipation: 63mW; Resistance: 5.1kR; Temperature Coefficient: 100ppm/°C; Voltage Rating: 50V; Case Code (Imperial): 0402; Case Code (Metric): 1005; Case/Package: 0402; Category: Chip SMD Resistors; Component Kind: Standard; ComponentLink1Description: https://datasheet.ciiva.com/2453/pyu-r-int-thick-7-2453400.pdf?src-supplier=Digikey; ComponentLink1URL: https://datasheet.ciiva.com/2453/pyu-r-int-thick-7-2453400.pdf?src-supplier=Digikey; ComponentLink2Description: https://datasheet.ciiva.com/2453/pyu-r-int-thick-7-2453401.pdf?src-supplier=Digikey; ComponentLink2URL: https://datasheet.ciiva.com/2453/pyu-r-int-thick-7-2453401.pdf?src-supplier=Digikey; ComponentLink3Description: https://datasheet.ciiva.com/2453/pyu-r-int-thick-7-2453402.pdf?src-supplier=Digikey; ComponentLink3URL: https://datasheet.ciiva.com/2453/pyu-r-int-thick-7-2453402.pdf?src-supplier=Digikey; ComponentLink4Description: https://datasheet.ciiva.com/12558/pyu-rc0402-51-rohs-l-6-r-8721-12558460.pdf?src-supplier=Mouser; ComponentLink4URL: https://datasheet.ciiva.com/12558/pyu-rc0402-51-rohs-l-6-r-8721-12558460.pdf?src-supplier=Mouser; ComponentLink5Description: https://datasheet.ciiva.com/46394/1018594-46394936.pdf?src-supplier=Element14; ComponentLink5URL: https://datasheet.ciiva.com/46394/1018594-46394936.pdf?src-supplier=Element14; ComponentLink6Description: https://datasheet.ciiva.com/15189/getdatasheetpartid-323636-15189493.pdf?src-supplier=Verical; ComponentLink6URL: https://datasheet.ciiva.com/15189/getdatasheetpartid-323636-15189493.pdf?src-supplier=Verical; ComponentLink7Description: https://datasheet.ciiva.com/7983/1018594-7983519.pdf?src-supplier=Element14; ComponentLink7URL: https://datasheet.ciiva.com/7983/1018594-7983519.pdf?src-supplier=Element14; ComponentLink8Description: https://datasheet.ciiva.com/16744/pyu-rc0402-51-rohs-l-6-r-16744283.pdf?src-supplier=Future+Electronics; ComponentLink8URL: https://datasheet.ciiva.com/16744/pyu-rc0402-51-rohs-l-6-r-16744283.pdf?src-supplier=Future+Electronics; ComponentLink9Description: LCSC; ComponentLink9URL: https://www.lcsc.com/product-detail/Chip-Resistor-Surface-Mount_YAGEO-RC0402FR-075K1L_C105872.html; Construction: Rectangular; Depth: 0.5mm; Features: Moisture Resistant; Height: 0.016inch; Lead Free: Lead Free; Length: 1mm; Max Operating Temperature: 155°C; Military Standard: Not; Min Operating Temperature: -55°C; Number of Terminations: 2; Package Quantity: 1; Packaging: Tape and Reel; Power Rating: 63mW; Pricing 1: 10000=0.0007, 50000=0.0006, 250000=0.0006, 1000000=0.0006, 2500000=0.0006 (USD); Reach SVHC Compliant: Unknown; Resistance Tolerance: 1%; Resistor Type: Thick Film; RoHS: Yes; RoHS Compliant: Yes; Series: RC; Stock 1: 2560000; Supplier: LCSC; Supplier 1: Future Electronics; Supplier Part Number: C105872; Supplier Part Number 1: 6053649; Technology: THICK FILM; Termination: SMD/SMT; Tolerance: 1%; Voltage Rating (DC): 50V; Width: 0.02inch; Working Voltage: 50V; Library Name: josh-lib.SchLib
R18-R22 = RC0402FR-07510RL: SMD Chip Resistor, 510 Ohm, ± 1%, 63 mW, 0402 [1005 Metric], Thick Film, General Purpose; Manufacturer: Yageo; Max Power Dissipation: 63mW; Resistance: 510R; Temperature Coefficient: 100ppm/°C; Voltage Rating: 50V; Case Code (Imperial): 0402; Case Code (Metric): 1005; Case/Package: 0402; Category: Chip SMD Resistors; Component Kind: Standard; ComponentLink1Description: https://datasheet.ciiva.com/2453/pyu-r-int-thick-7-2453398.pdf?src-supplier=Digi-Key; ComponentLink1URL: https://datasheet.ciiva.com/2453/pyu-r-int-thick-7-2453398.pdf?src-supplier=Digi-Key; ComponentLink2Description: https://datasheet.ciiva.com/2453/pyu-r-int-thick-7-2453399.pdf?src-supplier=Digi-Key; ComponentLink2URL: https://datasheet.ciiva.com/2453/pyu-r-int-thick-7-2453399.pdf?src-supplier=Digi-Key; ComponentLink3Description: https://datasheet.ciiva.com/2453/pyu-r-int-thick-7-2453397.pdf?src-supplier=Digi-Key; ComponentLink3URL: https://datasheet.ciiva.com/2453/pyu-r-int-thick-7-2453397.pdf?src-supplier=Digi-Key; ComponentLink4Description: https://datasheet.ciiva.com/17063/pyu-rc0402-51-rohs-l-6-r-17063430.pdf?src-supplier=Future+Electronics; ComponentLink4URL: https://datasheet.ciiva.com/17063/pyu-rc0402-51-rohs-l-6-r-17063430.pdf?src-supplier=Future+Electronics; ComponentLink5Description: LCSC; ComponentLink5URL: https://www.lcsc.com/product-detail/Chip-Resistor-Surface-Mount_YAGEO-RC0402FR-07510RL_C276273.html; Construction: Rectangular; Depth: 0.5mm; Features: Moisture Resistant; Height: 0.016inch; Lead Free: Lead Free; Length: 1mm; Manufacturer Part Number: RC0402FR-07510RL; Max Operating Temperature: 155°C; Military Standard: Not; Min Operating Temperature: -55°C; Number of Terminations: 2; Package Quantity: 1; Packaging: Tape and Reel; Power Rating: 63mW; Pricing 1: 10000=0.001, 50000=0.001, 300000=0.0009, 1000000=0.0009, 3000000=0.0008 (USD); Reach SVHC Compliant: Unknown; Resistance Tolerance: 1%; Resistor Type: Thick Film; RoHS: Yes; RoHS Compliant: Yes; Series: RC; Size Code: 0402; Stock 1: 130000; Supplier: LCSC; Supplier 1: Future Electronics; Supplier Part Number: C276273; Supplier Part Number 1: 6052880; Termination: SMD/SMT; Tolerance: 1%; Voltage Rating (DC): 50V; Weight: 2.3E-05oz; Width: 0.02inch; Library Name: josh-lib.SchLib
SW1-SW5 = CAP_TOUCH: Library Name: josh-lib.SchLib
SW8 SW9 = TS24CA: 1.8mm 3.5mm Rectangle button 50mA Horizontal attachment 4.55mm SPST 12V SMD Tactile Switches ROHS spst; Manufacturer: SHOU HAN; Component Kind: Standard; ComponentLink1Description: LCSC; ComponentLink1URL: https://www.lcsc.com/product-detail/Tactile-Switches_SHOU-HAN-TS24CA_C393942.html; Manufacturer Part Number: TS24CA; Supplier: LCSC; Supplier Part Number: C393942; Library Name: josh-lib.SchLib
U1 = ESP32-S3-MINI-1-N4R2: On-board PCB antenna SMD WiFi Modules ROHS; Manufacturer: Espressif Systems; Category: LDO; Component Kind: Standard; ComponentLink1Description: LCSC; ComponentLink1URL: https://www.lcsc.com/product-detail/WiFi-Modules_Espressif-Systems-ESP32-S3-MINI-1-N4R2_C3013941.html; Manufacturer Part Number: ESP32-S3-MINI-1-N4R2; Pricing 1: 1=3.01 (USD); RoHS: No; Stock 1: 387; Supplier: LCSC; Supplier 1: Digikey; Supplier Part Number: C3013941; Supplier Part Number 1: 1965-ESP32-S3-MINI-1-N4R2CT-ND; Library Name: josh-lib.SchLib
U2 U3 = TPS92511DDAR: 500mA 30W Anode Capable constant current buck LED Dvr 8-SO PowerPAD -40 to 125; Manufacturer: Texas Instruments; Dimming: Analog,PWM; Frequency: 500kHz; Max Output Voltage: 65V; Min Input Voltage: 4.5V; Min Output Voltage: 200mV; Number of Channels: 1; Quiescent Current: 2.8mA; Case/Package: HSOP; Category: LED Drivers; Component Kind: Standard; ComponentLink1Description: https://datasheet.ciiva.com/29602/tps92511-29602876.pdf?src-supplier=Digikey; ComponentLink1URL: https://datasheet.ciiva.com/29602/tps92511-29602876.pdf?src-supplier=Digikey; ComponentLink2Description: LCSC; ComponentLink2URL: https://www.lcsc.com/product-detail/LED-Drivers_Texas-Instruments-TPS92511DDAR_C114277.html; Lead Free: Lead Free; Manufacturer Part Number: TPS92511DDAR; Max Operating Temperature: 125°C; Max Output Current: 500mA; Max Supply Voltage: 65V; Min Operating Temperature: -40°C; Mount: Surface Mount; Number of Outputs: 1; Number of Pins: 8; Output Current per Channel: 500mA; Package Quantity: 2500; Packaging: Cut Tape; Pricing 1: 1=1.3, 10=1.165, 25=1.1056, 100=0.9083, 250=0.84904, 500=0.75032, 1000=0.59236 (USD); RoHS: Yes; RoHS Compliant: Yes; Series: -; Stock 1: 2967; Supplier: LCSC; Supplier 1: Digikey; Supplier Part Number: C114277; Supplier Part Number 1: 296-41001-1-ND; Switching Frequency: 500kHz; Library Name: josh-lib.SchLib
U4 = LMR51606XDBVR: SIMPLE SWITCHER® power converter with 4.5V-to-65V 0.6A synchronous buck and 25µA quiescent current 6-SOT-23 -40 to 150; Manufacturer: Texas Instruments; Case/Package: SOT-23; Category: Voltage Regulators - Switching; Component Kind: Standard; ComponentLink1Description: DigiKey; ComponentLink1URL: https://www.digikey.com/en/products/detail/texas-instruments/LMR51606XDBVR/22116882; ComponentLink2Description: LCSC; ComponentLink2URL: https://www.lcsc.com/product-detail/DC-DC-Converters_Texas-Instruments-LMR51606XDBVR_C21260865.html; Length: 2.9mm; Manufacturer Part Number: LMR51606XDBVR; Number of Pins: 6; Pricing 1: 1=0.96, 10=0.621, 50=0.621, 100=0.512, 1000=0.473, 10000=0.422 (USD); RoHS: No; Stock 1: 11828; Supplier: LCSC; Supplier 1: Mouser; Supplier Part Number: C21260865; Supplier Part Number 1: 595-LMR51606XDBVR; Thickness: 1.2mm; Width: 1.6mm; Library Name: josh-lib.SchLib
U5 = AMS1117-3.3: 1A 60dB@(120Hz) Fixed 3.3V Positive 18V SOT-223 Linear Voltage Regulators (LDO) ROHS; Manufacturer: UMW(Youtai Semiconductor Co., Ltd.); Category: Uncategorized; Component Kind: Standard; ComponentLink1Description: LCSC; ComponentLink1URL: https://www.lcsc.com/product-detail/Linear-Voltage-Regulators-LDO_UMW-Youtai-Semiconductor-Co-Ltd-AMS1117-3-3_C347222.html; Manufacturer Part Number: AMS1117-3.3; Pricing 1: 1=0.48, 10=0.388, 25=0.3552, 100=0.2644, 250=0.2396, 500=0.19828 (USD); RoHS: No; Supplier: LCSC; Supplier 1: Digi-Key; Supplier Part Number: C347222; Supplier Part Number 1: 4518-AMS1117-3.3DKR-ND; Library Name: josh-lib.SchLib
U6 = ALS-PT19-315C/L177/TR8: Light to Current Ambient Light Sensor Digital 3.3V/5V 2-Pin SMD T/R; Manufacturer: Everlight; Collector Emitter Breakdown Voltage: 5.5V; Dark Current: 100nA; Fall Time: 0.22ms; Lens Style: Flat; Number of Elements: 1; Polarity: NPN; Rise Time: 0.11ms; Wavelength: 630nm; Case/Package: SMD/SMT; Category: Sensors; Component Kind: Standard; ComponentLink1Description: https://datasheet.ciiva.com/12092/als-pt19-315c-l177-tr-datasheet-34741-12092024.pdf?src-supplier=Mouser; ComponentLink1URL: https://datasheet.ciiva.com/12092/als-pt19-315c-l177-tr-datasheet-34741-12092024.pdf?src-supplier=Mouser; ComponentLink2Description: https://datasheet.ciiva.com/4270/alspt19315cl177-tr8-1810-4270796.pdf?src-supplier=Arrow+Electronics; ComponentLink2URL: https://datasheet.ciiva.com/4270/alspt19315cl177-tr8-1810-4270796.pdf?src-supplier=Arrow+Electronics; ComponentLink3Description: LCSC; ComponentLink3URL: https://www.lcsc.com/product-detail/Ambient-Light-Sensors_Everlight-Elec-ALS-PT19-315C-L177-TR8_C146233.html; Manufacturer Part Number: ALS-PT19-315C/L177/TR8; Max Breakdown Voltage: 5.5V; Max Operating Temperature: 85°C; MFG: Everlight; Min Operating Temperature: -40°C; Mount: Surface Mount; MPN: https://www.lcsc.com/product-detail/Ambient-Light-Sensors_Everlight-Elec-ALS-PT19-315C-L177-TR8_C146233.html; Number of Pins: 2; Package Quantity: 1; Packaging: Tape and Reel; Pricing 1: 1=0.37, 5=0.314, 10=0.292, 25=0.2672, 50=0.2508, 100=0.2362, 500=0.20796, 1000=0.19788 (USD); Radiation Hardening: No; RoHS: Yes; RoHS Compliant: Yes; Series: -; Stock 1: 58753; Supplier 1: Digikey; Supplier Part Number 1: 1080-1244-1-ND; Library Name: josh-lib.SchLib
